# Altium schematic sheet bogdob.SchDoc(bogdob)
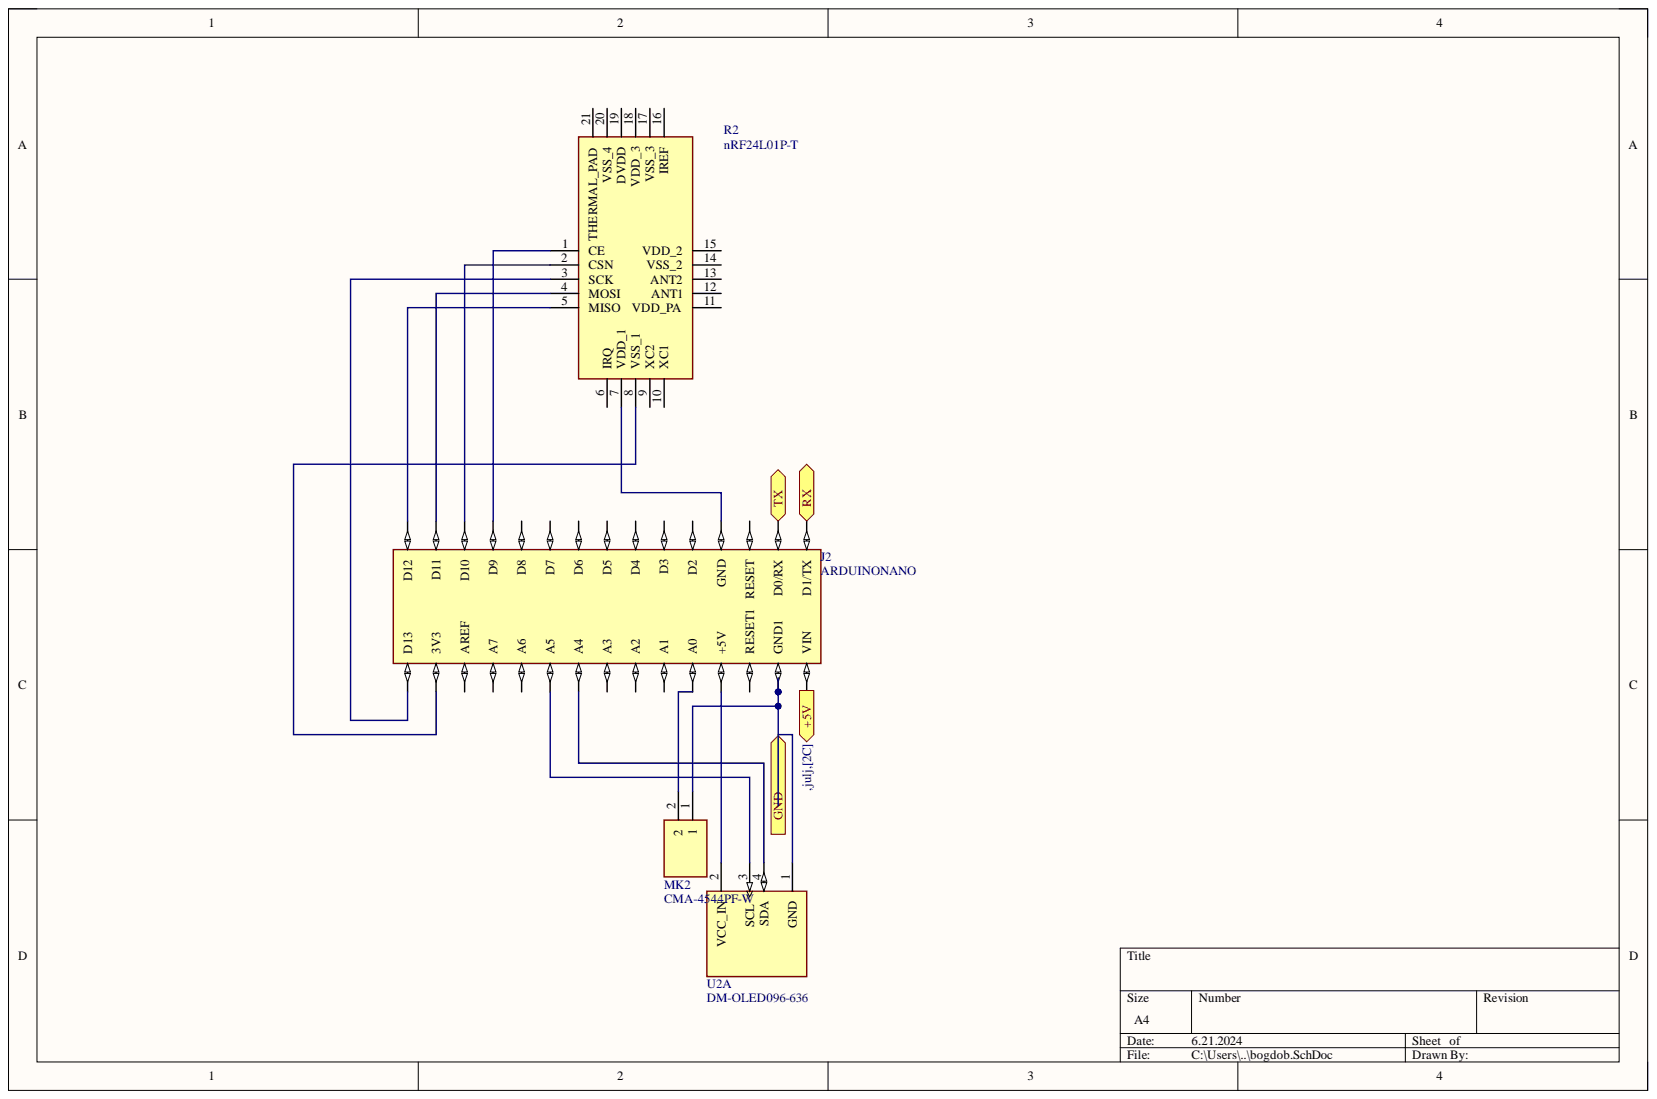
[diagram p0: bogdob.SchDoc]

## Parts
J2  ARDUINONANO  [PDIP-32]
MK2  CMA-4544PF-W  [CMA4544PFW]
R2  nRF24L01P-T  [QFN50P400X400X95-21N-D]
U2  DM-OLED096-636  [MODULE_DM-OLED096-636]  (part A)

## Ports
+5V, GND, RX, TX

## Nets
RX: J2.D1/TX ; port RX
TX: J2.D0/RX ; port TX
NetJ2_3V3: J2.3V3 R2.8(VSS_1)
NetJ2_+5V: J2.+5V U2.2(VCC_IN)
NetJ2_A0: J2.A0 MK2.2
NetJ2_A4: J2.A4 U2.4(SDA)
NetJ2_A5: J2.A5 U2.3(SCL)
NetJ2_D9: J2.D9 R2.1(CE)
NetJ2_D10: J2.D10 R2.2(CSN)
NetJ2_D11: J2.D11 R2.4(MOSI)
NetJ2_D12: J2.D12 R2.5(MISO)
NetJ2_D13: J2.D13 R2.3(SCK)
NetJ2_GND: J2.GND R2.7(VDD_1)
NetJ2_GND1: J2.GND1 MK2.1 U2.1(GND)
N00001: ; port +5V
N00002: ; port GND

Not connected: J2 A1 A2 A3 A6 A7 AREF D2 D3 D4 D5 D6 D7 D8 RESET RESET1 VIN; R2 6(IRQ) 9(XC2) 10(XC1) 11(VDD_PA) 12(ANT1) 13(ANT2) 14(VSS_2) 15(VDD_2) 16(IREF) 17(VSS_3) 18(VDD_3) 19(DVDD) 20(VSS_4) 21(THERMAL_PAD)

## Library data of the parts
J2 = ARDUINONANO: NINA-W102, RP2040 Arduino Nano RP2040 Connect with Headers series ARMÂ® CortexÂ®-M0+ MCU 32-Bit Embedded Evaluation Board; Availability: Not in stock; Check_prices: https://www.snapeda.com/parts/ARDUINONANO/Arduino/view-part/?ref=eda; Component Kind: Standard; Library Name: ARDUINONANO.IntLib; MF: Arduino; MP: ARDUINONANO; Package: Non-Standard Arduino; Price: None; SnapEDA_Link: https://www.snapeda.com/parts/ARDUINONANO/Arduino/view-part/?ref=snap
MK2 = CMA-4544PF-W: Microphone; Manufacturer_Name: CUI Devices; Arrow Part Number: CMA-4544PF-W; Arrow Price/Stock: https://www.arrow.com/en/products/cma-4544pf-w/cui-devices?region=nac; Component Kind: Standard; Datasheet Link: https://www.cuidevices.com/product/resource/cma-4544pf-w.pdf; Height: 4.65mm; Manufacturer_Part_Number: CMA-4544PF-W; Mouser Part Number: 490-CMA-4544PF-W; Mouser Price/Stock: https://www.mouser.co.uk/ProductDetail/CUI-Devices/CMA-4544PF-W?qs=WyjlAZoYn50RZRL7clQhww%3D%3D
R2 = nRF24L01P-T: Integrated Circuit; Manufacturer_Name: Nordic Semiconductor; Arrow Part Number: NRF24L01P-T; Arrow Price/Stock: null?region=nac; Component Kind: Standard; Datasheet Link: https://datasheet.datasheetarchive.com/originals/distributors/Datasheets-DGA19/4322.pdf; Height: 0.95mm; Manufacturer_Part_Number: nRF24L01P-T; Mouser Part Number: 949-NRF24L01P-T; Mouser Price/Stock: https://www.mouser.co.uk/ProductDetail/Nordic-Semiconductor/nRF24L01P-T?qs=yd0qOhnSiDf9ybbDsku6vg%3D%3D
U2 = DM-OLED096-636: 0.96â€ 128 X 64 MONOCHROME GRAPHIC OLED DISPLAY MODULE - I2C; Check_prices: https://www.snapeda.com/parts/DM-OLED096-636/Display+Module/view-part/?ref=eda; Component Kind: Standard; Library Name: DM-OLED096-636.SchLib; MF: Display Module; MP: DM-OLED096-636; Package: Package; SnapEDA_Link: https://www.snapeda.com/parts/DM-OLED096-636/Display+Module/view-part/?ref=snap
